# Altium schematic sheet Analog_Mulitiplexer.SchDoc
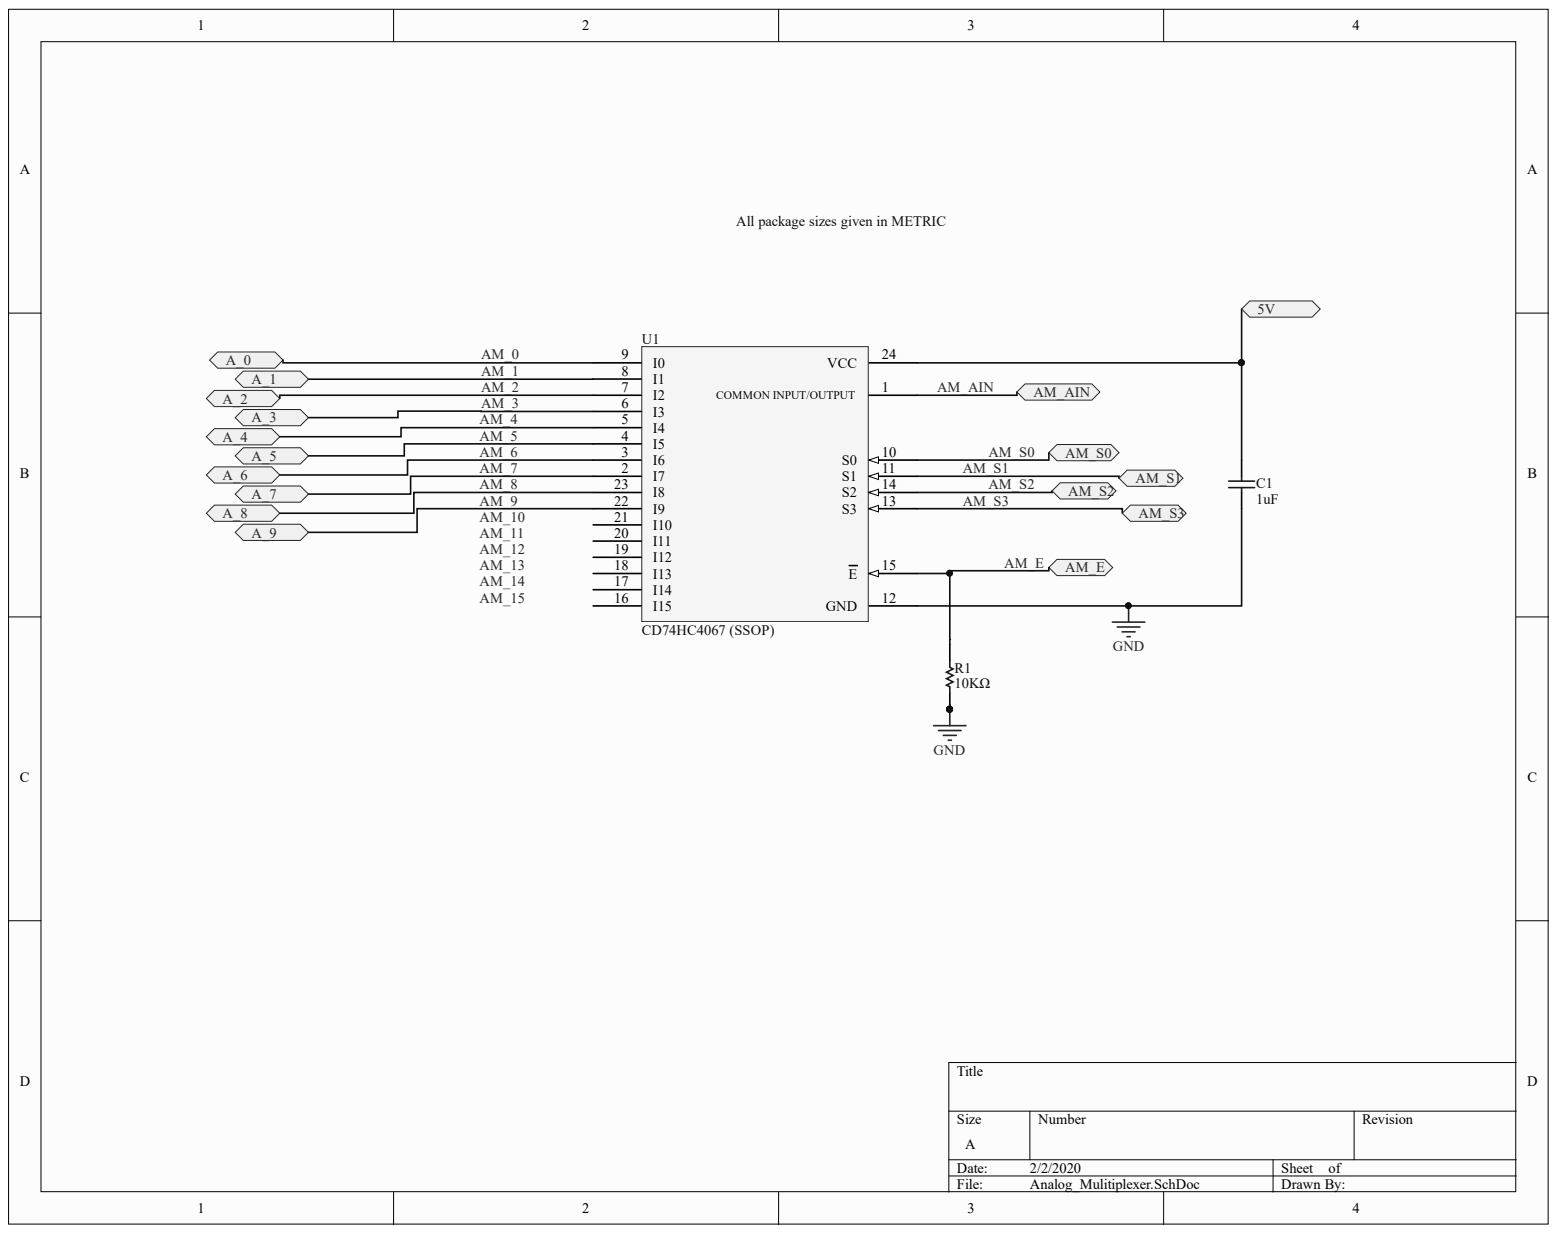
[diagram p0: Analog_Mulitiplexer.SchDoc]

## Parts
C1  1uF  [CAPC3225X94X50ML20T25]
R1  10KΩ  [RESC3225X60X45NL20T20]
U1  CD74HC4067 (SSOP)  [SOIC127P1030X265-24N]

## Ports
5V, A_0, A_1, A_2, A_3, A_4, A_5, A_6, A_7, A_8, A_9, AM_AIN, AM_E, AM_S0, AM_S1, AM_S2, AM_S3

## Nets
GND: C1.1 R1.2 U1.12(GND)
5V: C1.2 U1.24(VCC) ; port 5V
AM_0: U1.9(I0) ; port A_0
AM_1: U1.8(I1) ; port A_1
AM_2: U1.7(I2) ; port A_2
AM_3: U1.6(I3) ; port A_3
AM_4: U1.5(I4) ; port A_4
AM_5: U1.4(I5) ; port A_5
AM_6: U1.3(I6) ; port A_6
AM_7: U1.2(I7) ; port A_7
AM_8: U1.23(I8) ; port A_8
AM_9: U1.22(I9) ; port A_9
AM_AIN: U1.1 ; port AM_AIN
AM_E: R1.1 U1.15(E) ; port AM_E
AM_S0: U1.10(S0) ; port AM_S0
AM_S1: U1.11(S1) ; port AM_S1
AM_S2: U1.14(S2) ; port AM_S2
AM_S3: U1.13(S3) ; port AM_S3
AM_10:
AM_11:
AM_12:
AM_13:
AM_14:
AM_15:

Not connected: U1 16(I15) 17(I14) 18(I13) 19(I12) 20(I11) 21(I10)

## Library data of the parts
C1 = CMP-126956-2: CAP CER 0.15UF 50V 10% X7R 1210; Manufacturer Part Number: 12105C154KAT2A; Capacitance: 1.5e-07 F; Dielectric Material: Ceramic; Lead-Free Status: Lead Free; Voltage Rating (DC): 50.0 V; Capacitance Tolerance: ±10%; Case/Package: 1210; Component Kind: Standard; Dielectric Characteristic: X7R; Library Name: CommunityVault; Mounting Style: Surface Mount; Number of Pins: 2; OctopartID: f3ce3ac62c902c09; Octopart Url: https://octopart.com/12105c154kat2a-avx+interconnect+%2F+elco-195128; Packaging: Cut Tape (CT); RoHS: Compliant; Size-Height: 0.00094 m; Size-Length: 0.0032 m; Size-Width: 0.0025 m
R1 = CMP-1860323-2: RES SMD 10 OHM 1% 1/2W 1210; Manufacturer Part Number: CRCW121010R0FKEA; Lead-Free Status: Lead Free; Power Rating: 0.5 W; Resistance: 10.0 Ω; Voltage Rating (DC): 200.0 V; Case/Package: 1210; Component Kind: Standard; Library Name: CommunityVault; Mounting Style: Surface Mount; Number of Pins: 2; OctopartID: 62eca9b9d586bd2b; Octopart Url: https://octopart.com/crcw121010r0fkea-vishay-39817740; Packaging: Cut Tape (CT); REACH SVHC Compliance: No SVHC; Resistance Tolerance: ±1%; RoHS: Compliant; Size-Height: 0.00055 m; Size-Length: 0.0032 m; Size-Width: 0.0025 m
U1 = CMP-8e4b47469df5518c-1: High Speed CMOS 16-Channel Analog Multiplexer/Demultiplexer 24-SOIC -55 to 125; Component Kind: Standard; Library Name: CommunityVault; Mounting Style: Surface Mount
